# Altium schematic sheet DBMSch.SchDoc
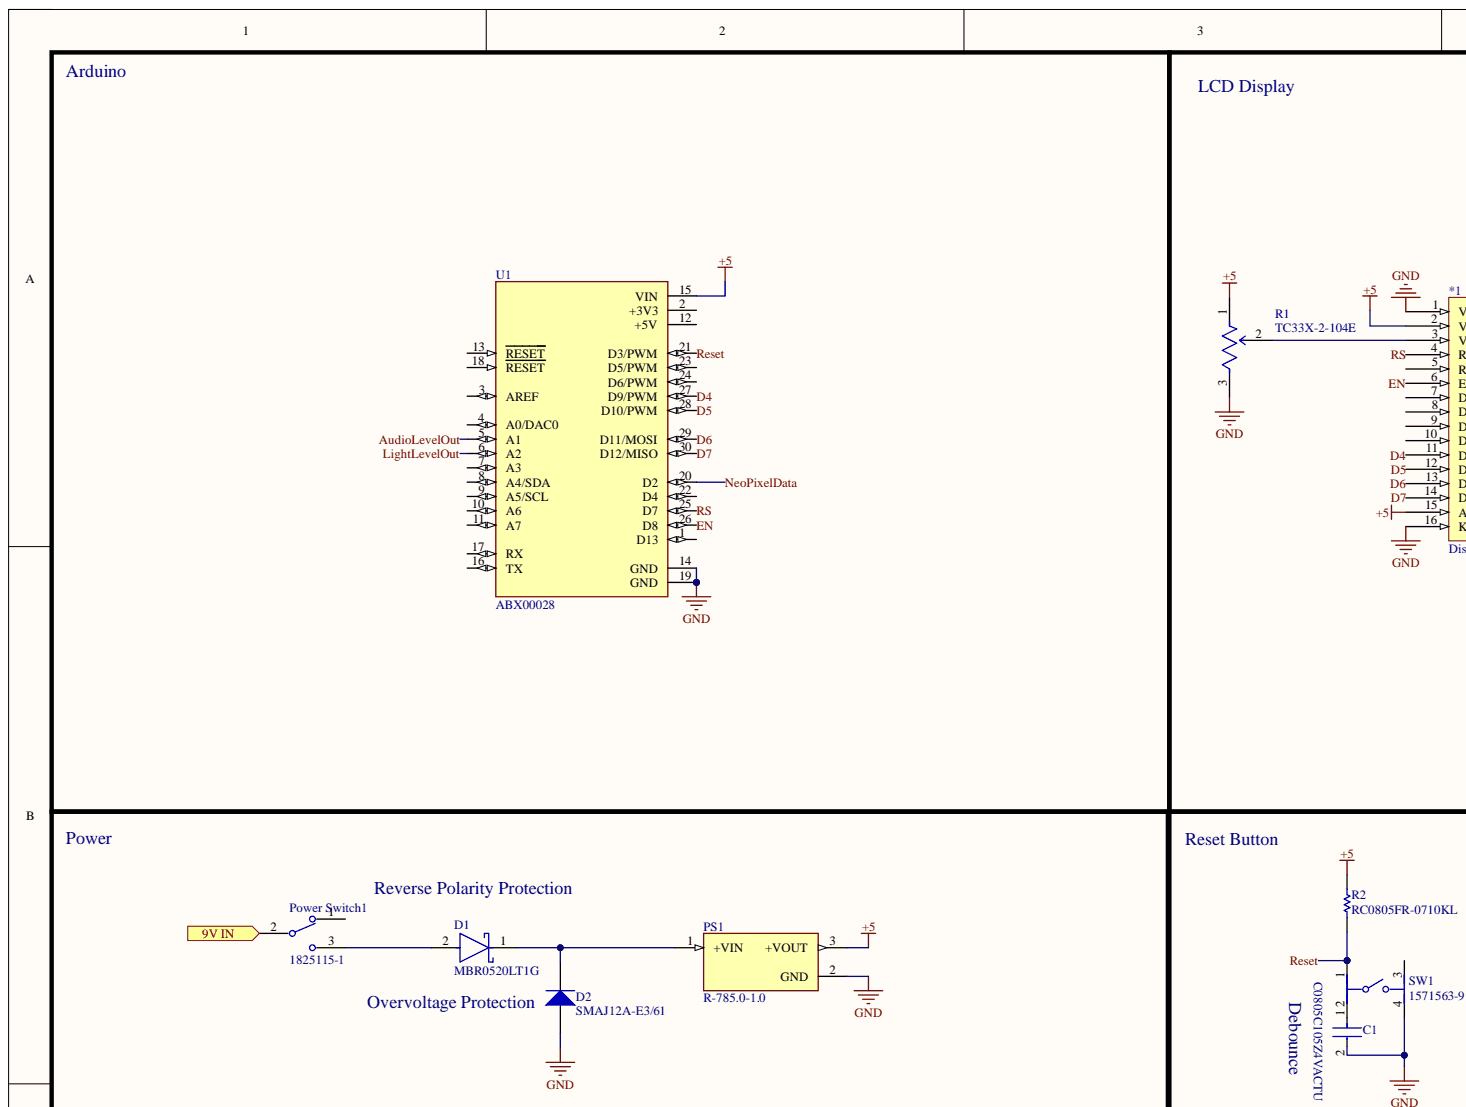
[diagram p0: DBMSch.SchDoc, top left]
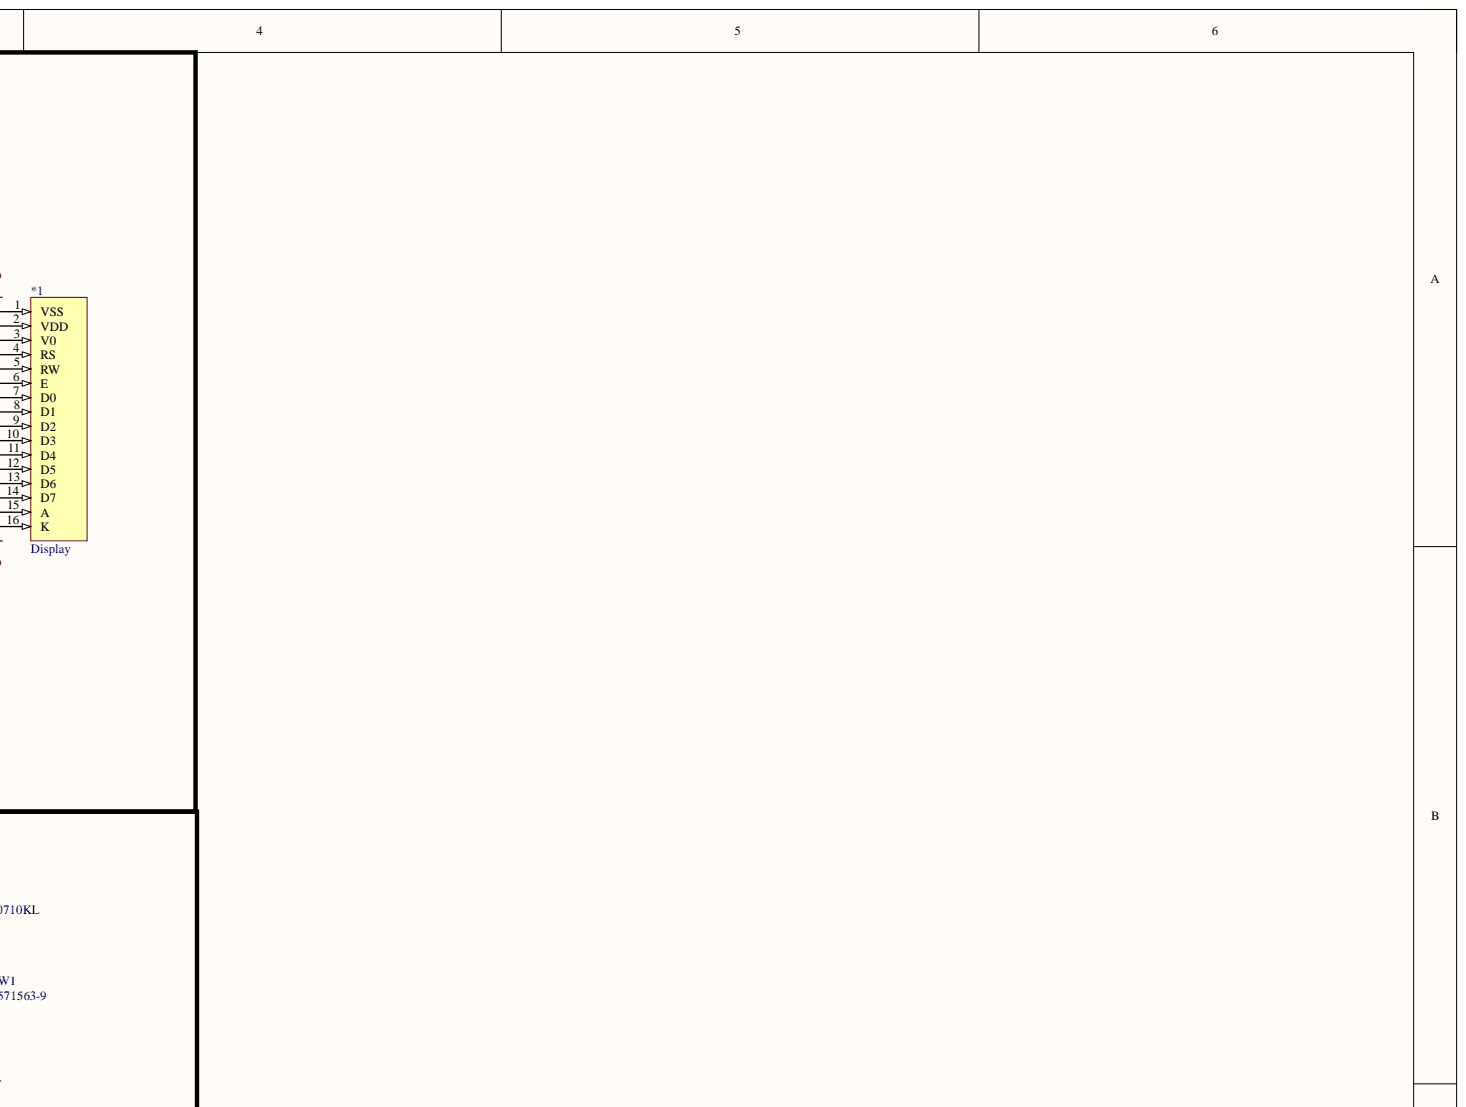
[diagram p1: DBMSch.SchDoc, top right]
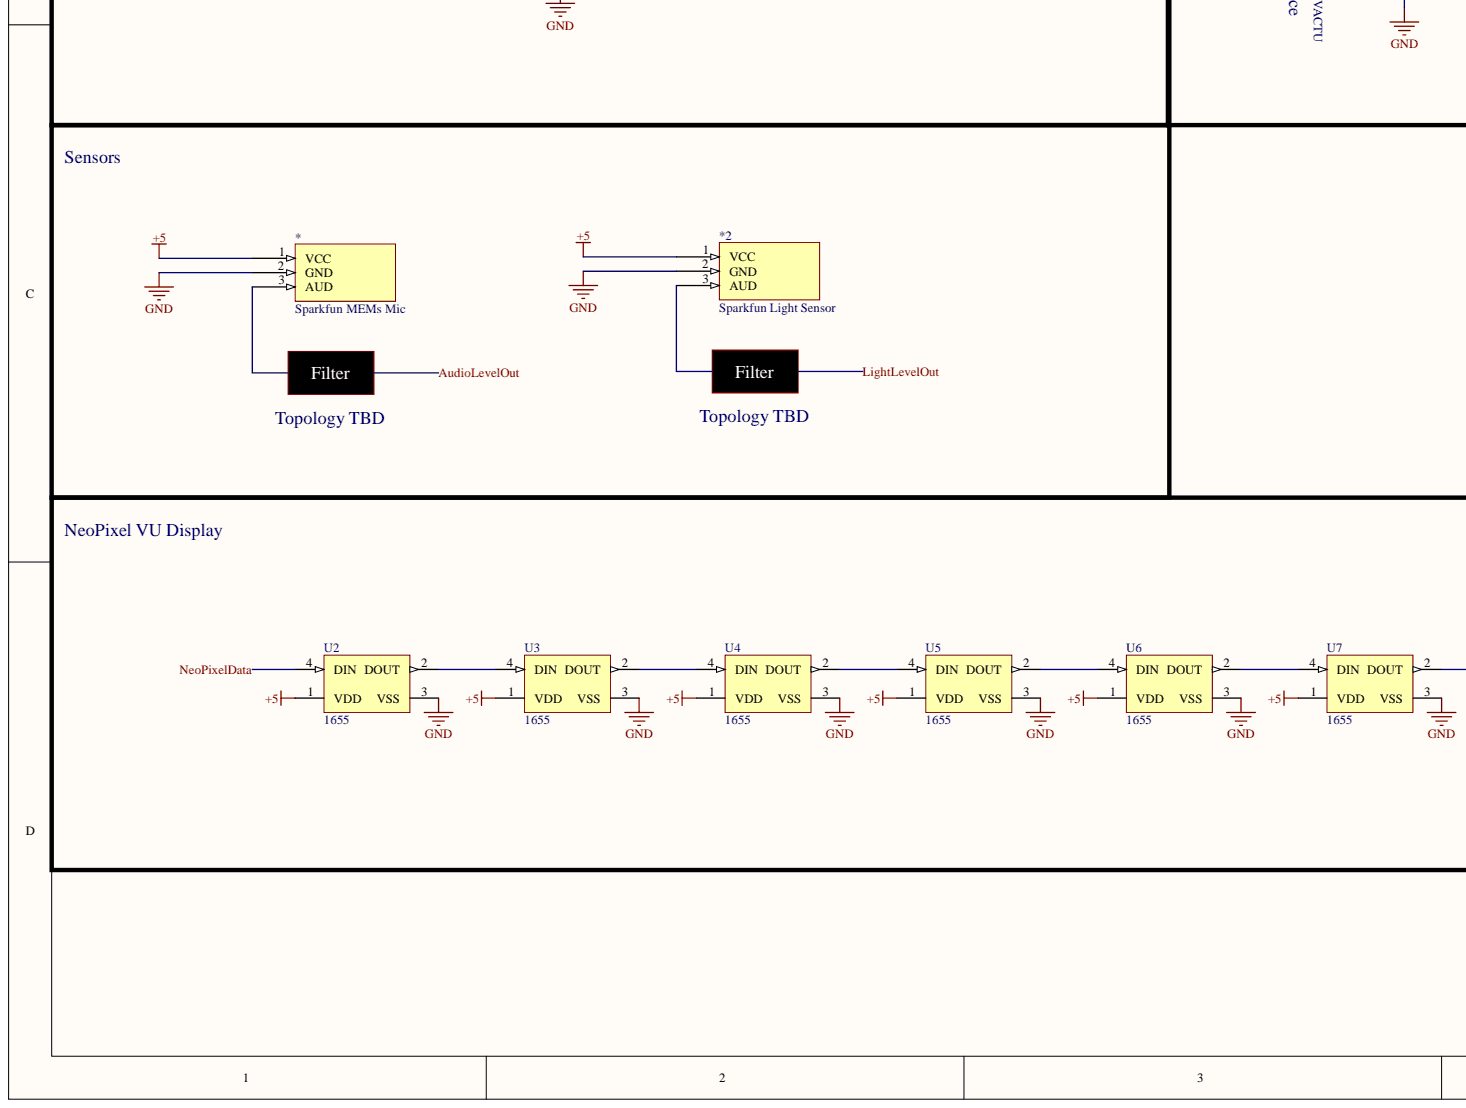
[diagram p2: DBMSch.SchDoc, bottom left]
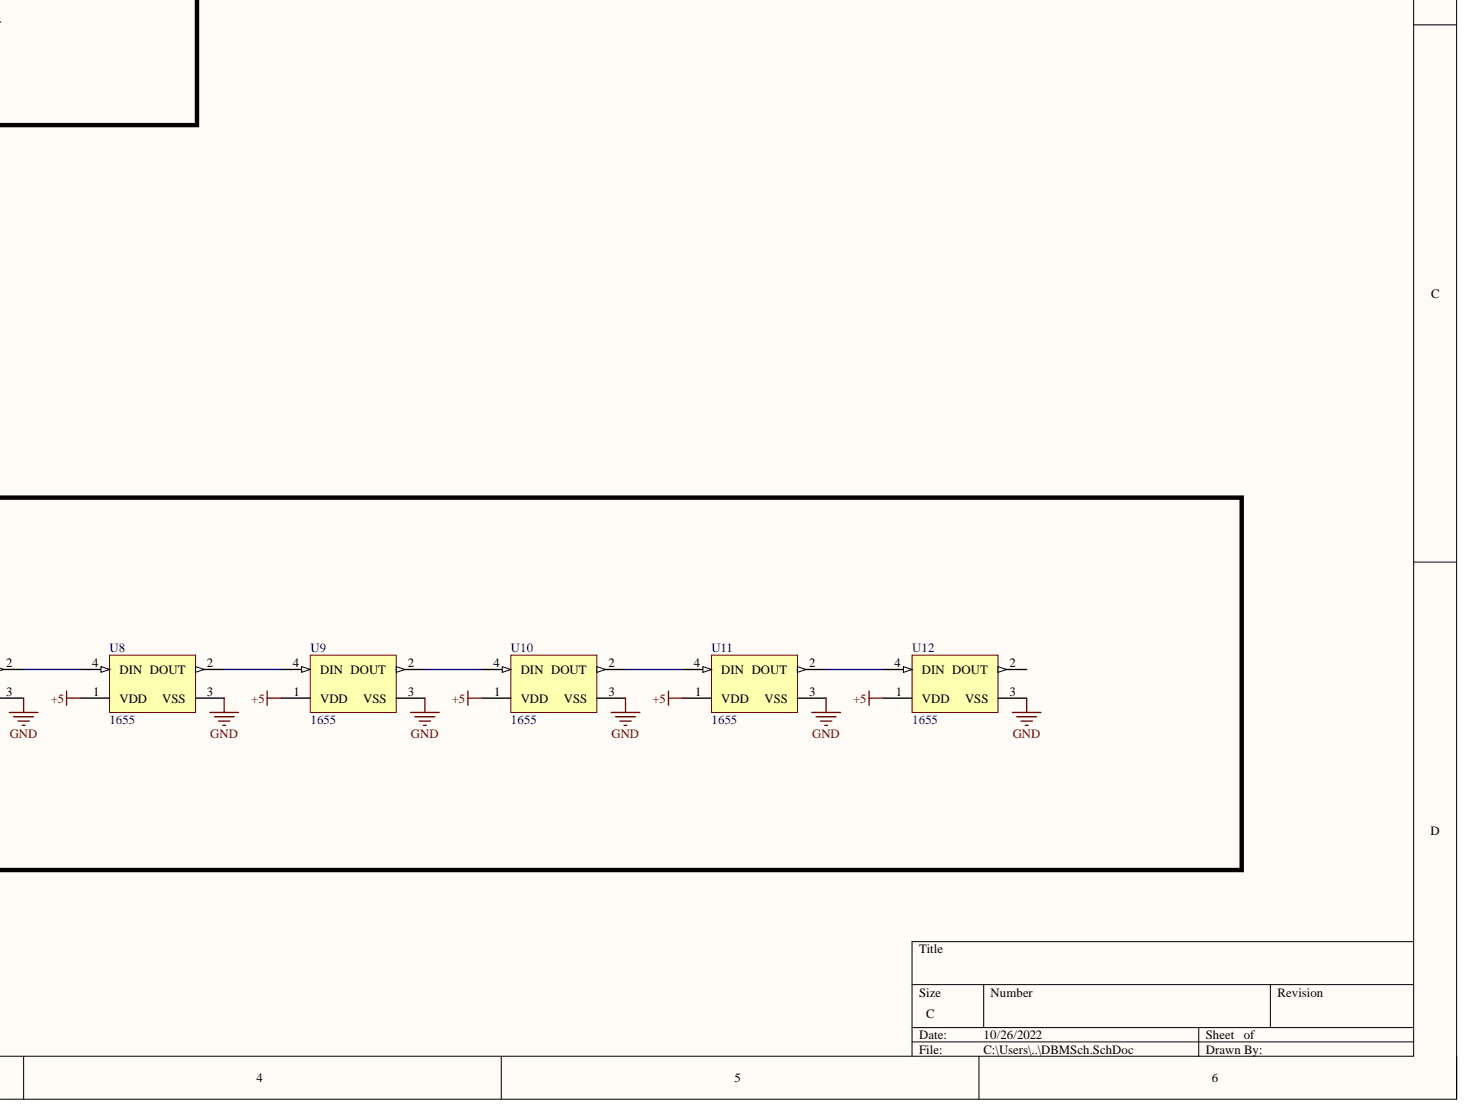
[diagram p3: DBMSch.SchDoc, bottom right]

## Parts
*  Sparkfun MEMs Mic
*1  Display  [Screen Header]
*2  Sparkfun Light Sensor
C1  C0805C105Z4VACTU  [FP-C0805C105Z4VACTU-MFG]
D1  MBR0520LT1G  [FP-425-04-IPC_C]
D2  SMAJ12A-E3/61  [VISH-DO-214AC-2_N]
Power_Switch1  1825115-1  [FP-1825115-1-MFG]
PS1  R-785.0-1.0  [CONV_R-785.0-1.0]
R1  TC33X-2-104E  [FP-TC33X-2-MFG]
R2  RC0805FR-0710KL  [RESC2013X60X35NL10T20]
SW1  1571563-9  [FP-1571563-9-MFG]
U1  ABX00028  [MODULE_ABX00028]
U2  1655  [ADA-RGB-1655_V]
U3  1655  [ADA-RGB-1655_V]
U4  1655  [ADA-RGB-1655_V]
U5  1655  [ADA-RGB-1655_V]
U6  1655  [ADA-RGB-1655_V]
U7  1655  [ADA-RGB-1655_V]
U8  1655  [ADA-RGB-1655_V]
U9  1655  [ADA-RGB-1655_V]
U10  1655  [ADA-RGB-1655_V]
U11  1655  [ADA-RGB-1655_V]
U12  1655  [ADA-RGB-1655_V]

## Ports
9V IN

## Nets
+5: *.1 *1.2(VDD) *1.15(A) *2.1 PS1.3 R1.1 R2.2 U1.15(VIN) U2.1(VDD) U3.1(VDD) U4.1(VDD) U5.1(VDD) U6.1(VDD) U7.1(VDD) U8.1(VDD) U9.1(VDD) U10.1(VDD) U11.1(VDD) U12.1(VDD)
GND: *.2 *1.1(VSS) *1.16(K) *2.2 C1.2 D2.2 PS1.2 R1.3 SW1.4 U1.14(GND) U1.19(GND) U2.3(VSS) U3.3(VSS) U4.3(VSS) U5.3(VSS) U6.3(VSS) U7.3(VSS) U8.3(VSS) U9.3(VSS) U10.3(VSS) U11.3(VSS) U12.3(VSS)
9V IN: Power_Switch1.2 ; port 9V IN
AudioLevelOut: U1.5(A1)
D4: *1.11(D4) U1.27(D9/PWM)
D5: *1.12(D5) U1.28(D10/PWM)
D6: *1.13(D6) U1.29(D11/MOSI)
D7: *1.14(D7) U1.30(D12/MISO)
EN: *1.6(E) U1.26(D8)
LightLevelOut: U1.6(A2)
NeoPixelData: U1.20(D2) U2.4(DIN)
Reset: R2.1 SW1.1 U1.21(D3/PWM)
RS: *1.4(RS) U1.25(D7)
Net*1_3: *1.3(V0) R1.2
NetC1_1: C1.1 SW1.2
NetD1_1: D1.1 D2.1 PS1.1
NetD1_2: D1.2 Power_Switch1.3
NetU2_2: U2.2(DOUT) U3.4(DIN)
NetU3_2: U3.2(DOUT) U4.4(DIN)
NetU4_2: U4.2(DOUT) U5.4(DIN)
NetU5_2: U5.2(DOUT) U6.4(DIN)
NetU6_2: U6.2(DOUT) U7.4(DIN)
NetU7_2: U7.2(DOUT) U8.4(DIN)
NetU8_2: U8.2(DOUT) U9.4(DIN)
NetU9_2: U9.2(DOUT) U10.4(DIN)
NetU10_2: U10.2(DOUT) U11.4(DIN)
NetU11_2: U11.2(DOUT) U12.4(DIN)

Not connected: * 3; *1 5(RW) 7(D0) 8(D1) 9(D2) 10(D3); *2 3; Power_Switch1 1; SW1 3; U1 1(D13) 2(+3V3) 3(AREF) 4(A0/DAC0) 7(A3) 8(A4/SDA) 9(A5/SCL) 10(A6) 11(A7) 12(+5V) 13(RESET) 16(TX) 17(RX) 18(RESET) 22(D4) 23(D5/PWM) 24(D6/PWM); U12 2(DOUT)

## Library data of the parts
*2 = Sparkfun MEMs Mic: Component Kind: Standard; Library Name: DBM.SchLib
C1 = CMP-2007-03241-2: CAP CER 1UF 16V Y5V 0805; Dielectric Material: Ceramic; Dissipation Factor: 7%; Tolerance: 80%; Capacitance: 1uF; Case Code (Imperial): 0805; Case Code (Metric): 2012; Case/Package: 0805; Component Kind: Standard; Construction: Flat; Contact Material: Tin; Depth: 1.25mm; Dielectric: Y5V; Height: 1.25mm; Lead Free: Lead Free; Lead Pitch: 0.75mm; Length: 2mm; Library Name: Altium Content Vault; Max Operating Temperature: 85°C; Min Operating Temperature: -30°C; Mount: Surface Mount; Number of Pins: 2; Package Quantity: 1; Packaging: Tape and Reel; Radiation Hardening: No; REACH SVHC: No SVHC; RoHS Compliant: Yes; Series: C; Termination: SMD/SMT; Thickness: 0.055inch; Voltage: 16V; Voltage Rating: 16V; Voltage Rating (DC): 16V; Width: 0.049inch
D1 = CMP-1055-00425-2: DIODE SCHOTTKY 20V 500MA SOD123; Element Configuration: Single; Forward Voltage: 385mV; Max Forward Surge Current (Ifsm): 5.5A; Max Reverse Leakage Current: 250uA; Polarity: Standard; Average Rectified Current: 500mA; Case/Package: SOD-123-2; Component Kind: Standard; Contact Plating: Tin; Current Rating: 500mA; Forward Current: 500mA; Height: 1.12mm; Lead Free: Lead Free; Length: 2.69mm; Library Name: Altium Content Vault; Max Operating Temperature: 125°C; Max Repetitive Reverse Voltage (Vrrm): 20V; Max Reverse Voltage (DC): 20V; Max Surge Current: 5.5A; Min Operating Temperature: -65°C; Number of Pins: 2; Output Current: 500mA; Package Quantity: 3000; Packaging: Tape and Reel; Peak Non-Repetitive Surge Current: 5.5A; Peak Reverse Current: 250uA; Radiation Hardening: No; REACH SVHC: No SVHC; Termination: SMD/SMT; Voltage Rating (DC): 20V; Width: 1.6mm
D2 = CMP-0544-00020-2: Transient Voltage Suppressor, 6.40 to 231 V, 12 V Stand-off Voltage, Uni-Directional, -55 to 155 degC, 2-pin DO-214AC, Pb-Free, Tape and Reel; Manufacturer: Vishay Semiconductor; ID (uA): 1; IFSM: 40 A; PPPM (W): 400, 300; VBR Uni-Directional (V): 6.4 to 231; VWM (V): 12; Component Kind: Standard; ComponentLink1Description: Manufacturer URL; ComponentLink1URL: http://www.vishay.com/; ComponentLink2Description: Datasheet; ComponentLink2URL: http://www.vishay.com/docs/88390/smaj50a.pdf; ComponentLink3Description: Package Specification; ComponentLink3URL: http://www.vishay.com/docs/88390/smaj50a.pdf; DatasheetVersion: 12/8/2013; Library Name: Altium Content Vault; Mounting Technology: Surface Mount; Operating Junction and Storage Temperature Range: -55 to 150 degC; PackageDescription: 2-Pin DO-214AC (SMA), Body 4.245 x 2.665 mm; PackageReference: DO-214AC-2; Packing: Tape and Reel; PartNumber: SMAJ12A-E3/61; RoHS: TRUE
Power_Switch1 = CMP-03405-000003-1: Slide Switch SPDT Through Hole; Manufacturer: TE Connectivity; Contact Current Rating: 250mA; Contact Resistance: 30mΩ; Electromechanical Life: 6000Cycles; Operating Force: 250gf; Voltage Rating Ac: 125V; Voltage Rating Dc: 12V; Automotive: No; Category: Switch; Component Kind: Standard; ComponentLink2Description: Datasheet; ComponentLink2URL: https://www.te.com/usa-en/product-1825115-1.html?te_bu=Cor&te_type=other&te_campaign=oct_glo_cor-oct-global-oth-prtnr-fy19-oct-octo-buy-bom_sma-298_2&elqCampaignId=35216; Device Class L1: Electromechanical; Device Class L2: Switches; Device Class L3: Slide Switches; Digikey Description: SWITCH SLIDE SPDT 250MA 125V; Lead Free: Yes; Library Name: Altium Content Vault; Manufacturer Part Number: 1825115-1; Mount: Through Hole; Octopart: https://octopart.com/sls121pc04-te+connectivity-39426454; Package: 1825115-1; RoHS: TRUE; Temperature: -10°C to +85°C; Temperature Range High: +85°C; Temperature Range Low: -10°C; Throw Configuration: SPDT
PS1 = R-785.0-1.0: 1.0 Amp SIP3 Single Output; MF: {'manufacturer': u'Recom Power'}; Availability: Unavailable; Component Kind: Standard; MP: R-785.0-1.0; Package: None; Price: None
R1 = CMP-07234-000040-1: TRIMMER 100K OHM 0.1W J LEAD TOP; Max Power Dissipation: 100mW; Number of Turns: 1; Resistance: 100kR; Resistance Tolerance: 25%; Temperature Coefficient: 250ppm/°C; Voltage Rating (DC): 50V; Color: Silver; Component Kind: Standard; Depth: 3.8mm; Height: 0.047inch; Length: 3mm; Library Name: Altium Content Vault; Max Operating Temperature: 100°C; Military Standard: Not; Min Operating Temperature: -40°C; Mount: Surface Mount; Package Quantity: 2500; Packaging: Tape and Reel; Power Rating: 100mW; Radiation Hardening: No; REACH SVHC: No SVHC; Resistor Type: Carbon; RoHS Compliant: Yes; Series: TC33; Termination: Foot Print; Tolerance: 25%; Weight: 29.4mg; Width: 0.118inch
R2 = CMP-1659-00013-4: Chip Resistor, 10 KOhm, +/- 1%, 0.125 W, -55 to 155 degC, 0805 (2012 Metric), RoHS, Tape and Reel; Manufacturer: Yageo; Voltage Rating: 150 V; Component Kind: Standard; ComponentLink1Description: Manufacturer URL; ComponentLink1URL: http://www.yageo.com/; ComponentLink2Description: Datasheet; ComponentLink2URL: http://www.yageo.com/documents/recent/PYu-RC_Group_51_RoHS_L_04.pdf; DatasheetVersion: Rev. 4, 02/2016; Height: 0.5 mm; Length: 2 mm; Library Name: Altium Content Vault; Max Operating Temperature: 155 degC; Min Operating Temperature: -55 degC; Mount: Surface Mount; Mounting Technology: Surface Mount; Package Quantity: 5000; PackageDescription: 2-Pin Surface Mounted Device, Body 2 x 1.25 mm; PackageReference: 0805; Packaging: Tape and Reel; Pins: 2; REACH SVHC: No SVHC; RoHSCompliant: true
SW1 = CMP-03402-000005-1: Tactile Switch SPST-NO Top Actuated Surface Mount; Manufacturer: TE Connectivity; Contact Current Rating: 50mA; Contact Resistance: 100mΩ; Electromechanical Life: 100000Cycles; Operating Force: 160gf; Standoff Height: 0.05mm; Voltage Rating Dc: 24V; Automotive: No; Category: Switch; Component Kind: Standard; ComponentLink2Description: Datasheet; ComponentLink2URL: https://www.te.com/commerce/DocumentDelivery/DDEController?Action=showdoc&DocId=Customer+Drawing%7F2-1437565-7%7FV%7Fpdf%7FEnglish%7FENG_CD_2-1437565-7_V.pdf%7F1571563-9; Device Class L1: Electromechanical; Device Class L2: Switches; Device Class L3: Pushbutton Switches; Digikey Description: SWITCH TACTILE SPST-NO 0.05A 24V; Height: 9.75mm; Lead Free: Yes; Library Name: Altium Content Vault; Manufacturer Part Number: 1571563-9; Mount: Surface Mount; Mouser Description: Tactile Switches SPST OFF(ON) RND SMT MINI PB TACT SWITCH; Octopart: https://octopart.com/1571563-9-te+connectivity-41253969; Package: SMT_SW_6MM0_6MM0; RoHS: TRUE; Temperature: -35°C to +85°C; Temperature Range High: +85°C; Temperature Range Low: -35°C; Throw Configuration: SPST-NO
U1 = ABX00028: ATmega4809 Arduino Nano Every AVR AVR MCU 8-Bit Embedded Evaluation Board; MF: {'manufacturer': u'Arduino'}; Availability: Unavailable; Component Kind: Standard; MP: ABX00028; Package: None; Price: None
U2-U12 = CMP-2000-05026-1: Intelligent Control LED Integrated Light Source, 3.5 to 5.3 V, -25 to 80 degC, 4-Pin SMD, RoHS, Tape and Reel; Manufacturer: Adafruit-Industries-LLC; Configuration: Discrete; Number of LEDs: 10; Utilized LED: WS2812(5050); Category: Optoelectronics; Color: Red,Green,Blue(RGB); Component Kind: Standard; Controller Type: -; Datasheet URL: http://datasheet.octopart.com/ADA1655-Adafruit-Industries-datasheet-32028139.pdf; Datasheet Version: May-13; Family: Addressable,Specialty; Interface: -; Library Name: Altium Content Vault; Manufacturer URL: https://www.adafruit.com/; Mounting Type: SurfaceMount; Package Description: 4-Pin Surface Mount Device, Body 5 x 5 mm; Package Reference: 1655; Series: Neopixel; Size / Dimension: 5.00mmLx5.00mmW
* = Sparkfun MEMs Mic: Component Kind: Standard; Library Name: DBM.SchLib
*1 = Display: Component Kind: Standard; Library Name: RiceCookerLib.SchLib
